# Altium schematic sheet Sheet1.SchDoc
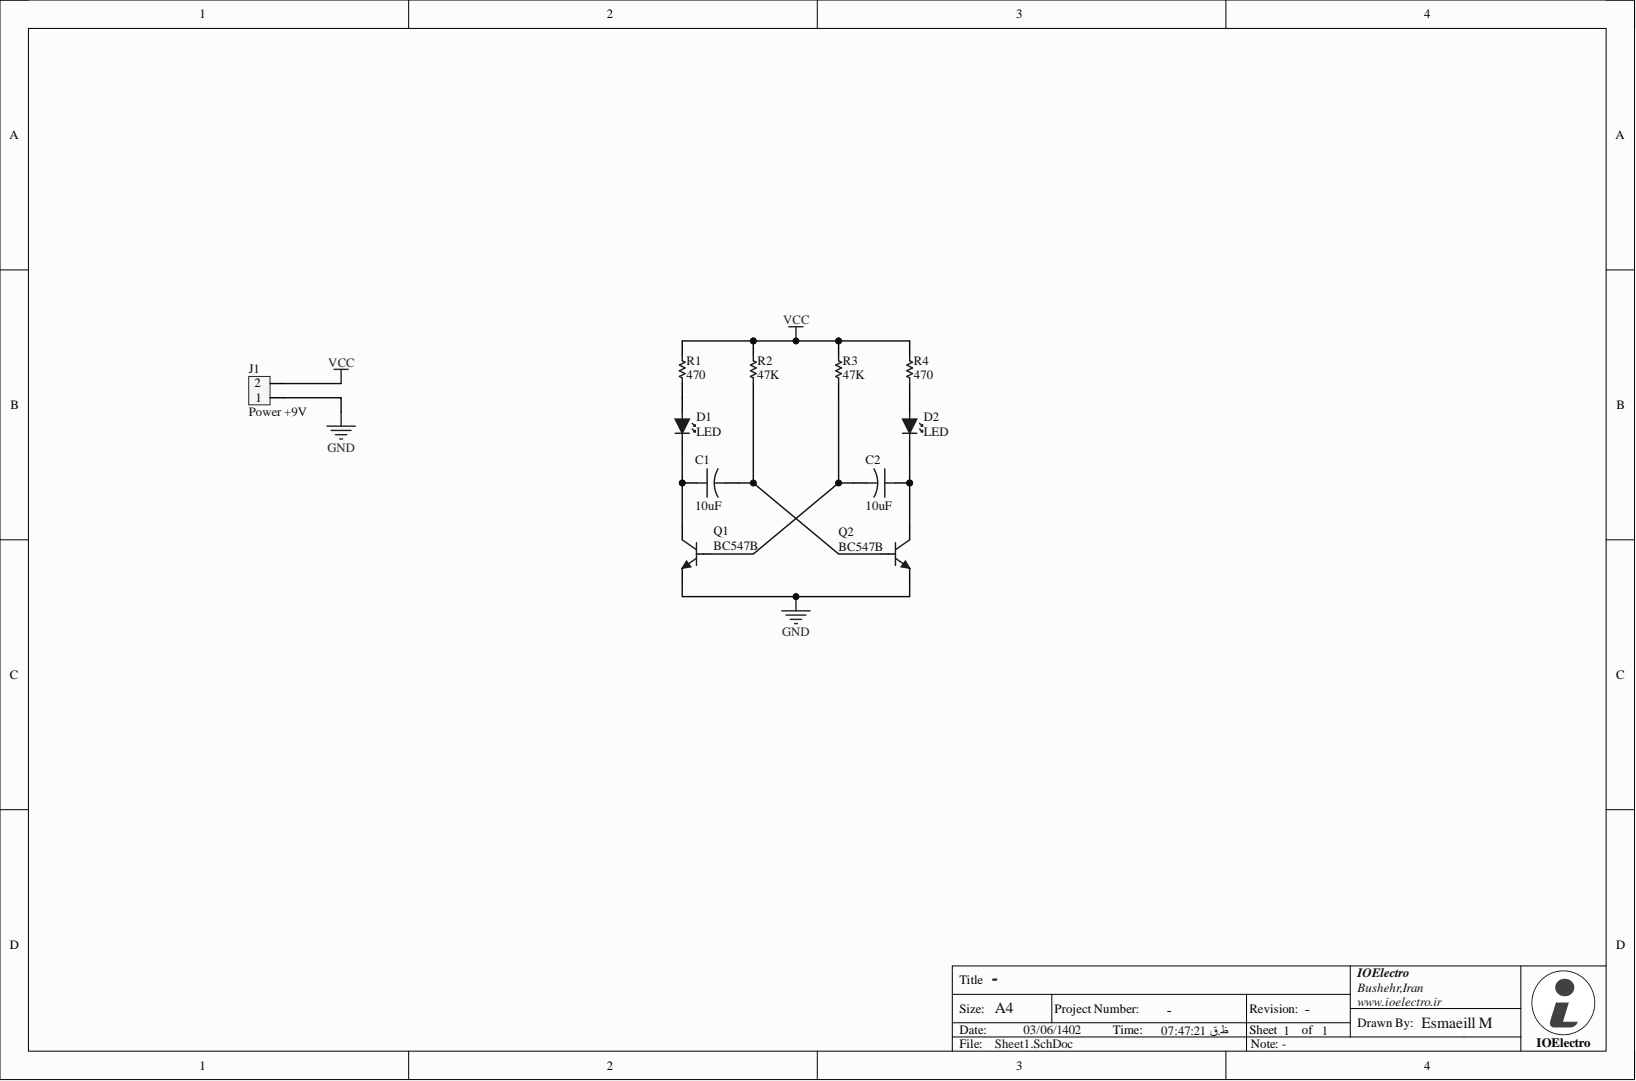
[diagram p0: Sheet1.SchDoc]

## Parts
C1  10uF  [C-EL_5x11x2mm]
C2  10uF  [C-EL_5x11x2mm]
D1  LED  [LED-3MM]
D2  LED  [LED-3MM]
J1  Power +9V  [PH-1X2-N3D]
Q1  BC547B  [TO-92]
Q2  BC547B  [TO-92]
R1  470  [R-1/4W-DIP]
R2  47K  [R-1/4W-DIP]
R3  47K  [R-1/4W-DIP]
R4  470  [R-1/4W-DIP]

## Nets
GND: J1.1 Q1.3 Q2.3
VCC: J1.2 R1.2 R2.2 R3.2 R4.2
NetC1_A: C1.A D1.K Q1.1
NetC1_K: C1.K Q2.2 R2.1
NetC2_A: C2.A D2.K Q2.1
NetC2_K: C2.K Q1.2 R3.1
NetD1_A: D1.A R1.1
NetD2_A: D2.A R4.1

## Library data of the parts
C1 C2 = CAP-EL: CAPACITOR ELECTROLYTIC; Component Kind: Standard; Library Name: IOElectro.IntLib
J1 = PH-1X2: PIN HEADER 1x2 2.56mm; Component Kind: Standard; Library Name: IOElectro.IntLib
Q1 Q2 = BC547B: NPN TRANSISTOR 1.5A 40V SOT-23 R; Manufacturer: ON Semiconductor / Fairchild; Collector Base Voltage (VCBO): 50V; Collector Emitter Breakdown Voltage: 45V; Collector Emitter Saturation Voltage: 300mV; Current Rating: 100mA; Element Configuration: Single; Emitter Base Voltage (VEBO): 6V; Frequency: 300MHz; Gain Bandwidth Product: 100MHz; hFE Min: 200; Max Power Dissipation: 500mW; Number of Elements: 1; Case/Package: TO-92; Category: BJTs; Collector Emitter Voltage (VCEO): 45V; Component Kind: Standard; ComponentLink1Description: https://datasheet.ciiva.com/17011/bc547-17011404.pdf?src-supplier=Future+Electronics; ComponentLink1URL: https://datasheet.ciiva.com/17011/bc547-17011404.pdf?src-supplier=Future+Electronics; ComponentLink2Description: https://datasheet.ciiva.com/985/bc546-547-548rev5-985523.pdf?src-supplier=Digi-Key; ComponentLink2URL: https://datasheet.ciiva.com/985/bc546-547-548rev5-985523.pdf?src-supplier=Digi-Key; ComponentLink3Description: https://datasheet.ciiva.com/972/bc546-972262.pdf?src-supplier=Digi-Key; ComponentLink3URL: https://datasheet.ciiva.com/972/bc546-972262.pdf?src-supplier=Digi-Key; ComponentLink4Description: https://datasheet.ciiva.com/1195/1868820-1195464.pdf?src-supplier=Element14; ComponentLink4URL: https://datasheet.ciiva.com/1195/1868820-1195464.pdf?src-supplier=Element14; ComponentLink5Description: https://datasheet.ciiva.com/25996/bc550-25996616.pdf?src-supplier=Avnet+Europe; ComponentLink5URL: https://datasheet.ciiva.com/25996/bc550-25996616.pdf?src-supplier=Avnet+Europe; ComponentLink6Description: https://datasheet.ciiva.com/11197/bc550-11197769.pdf?src-supplier=Avnet; ComponentLink6URL: https://datasheet.ciiva.com/11197/bc550-11197769.pdf?src-supplier=Avnet; ComponentLink7Description: https://datasheet.ciiva.com/16472/bc550-16472201.pdf?src-supplier=Avnet+Asia; ComponentLink7URL: https://datasheet.ciiva.com/16472/bc550-16472201.pdf?src-supplier=Avnet+Asia; ComponentLink8Description: http://www.comchiptech.com/admin/files/product/SS8050-G RevA181526.pdf?src-supplier=Digi-Key; ComponentLink8URL: http://www.comchiptech.com/admin/files/product/SS8050-G RevA181526.pdf?src-supplier=Digi-Key; ComponentLink9Description: http://www.comchiptech.com/cms/UserFiles/SS8050-G%20RevA181526.pdf?src-supplier=Digi-Key; ComponentLink9URL: http://www.comchiptech.com/cms/UserFiles/SS8050-G%20RevA181526.pdf?src-supplier=Digi-Key; Contact Plating: Copper,Tin,Silver; Height: 4.58mm; Lead Free: Lead Free; Length: 4.58mm; Library Name: IOElectro.IntLib; Manufacturer Part Number: BC547B; Max Breakdown Voltage: 45V; Max Collector Current: 100mA; Max Frequency: 300MHz; Max Operating Temperature: 150°C; Min Operating Temperature: -65°C; Mount: Through Hole; Number of Pins: 3; Package Quantity: 2000; Packaging: Bulk; Polarity: NPN; Power Dissipation: 500mW; Pricing 1: 1=0/50000, 10=0/36900, 25=0/32280, 100=0/20890, 500=0/13832, 1000=0/10605, 2500=0/09222, 5000=0/08300 (USD); Pricing 2: 1=0.24000, 10=0.20000, 100=0.10620, 500=0.06986, 1000=0.04751 (USD); Radiation Hardening: No; REACH SVHC: No SVHC; RoHS: Yes; Stock 1: 3549; Stock 2: 349867; Supplier 1: Digi-Key; Supplier 2: Digi-Key; Supplier Part Number 1: BC547BFS-ND; Supplier Part Number 2: 641-1790-1-ND; Transition Frequency: 300MHz; Voltage: 40V; Voltage Rating (DC): 45V; Weight: 0.007055oz; Width: 3.86mm
R1-R4 = RES: Component Kind: Standard; Library Name: IOElectro.IntLib
D1 D2 = LED: Component Kind: Standard; Description: LED; Library Name: IOElectro.IntLib
